# Altium schematic sheet LCD.SchDoc(LCD)
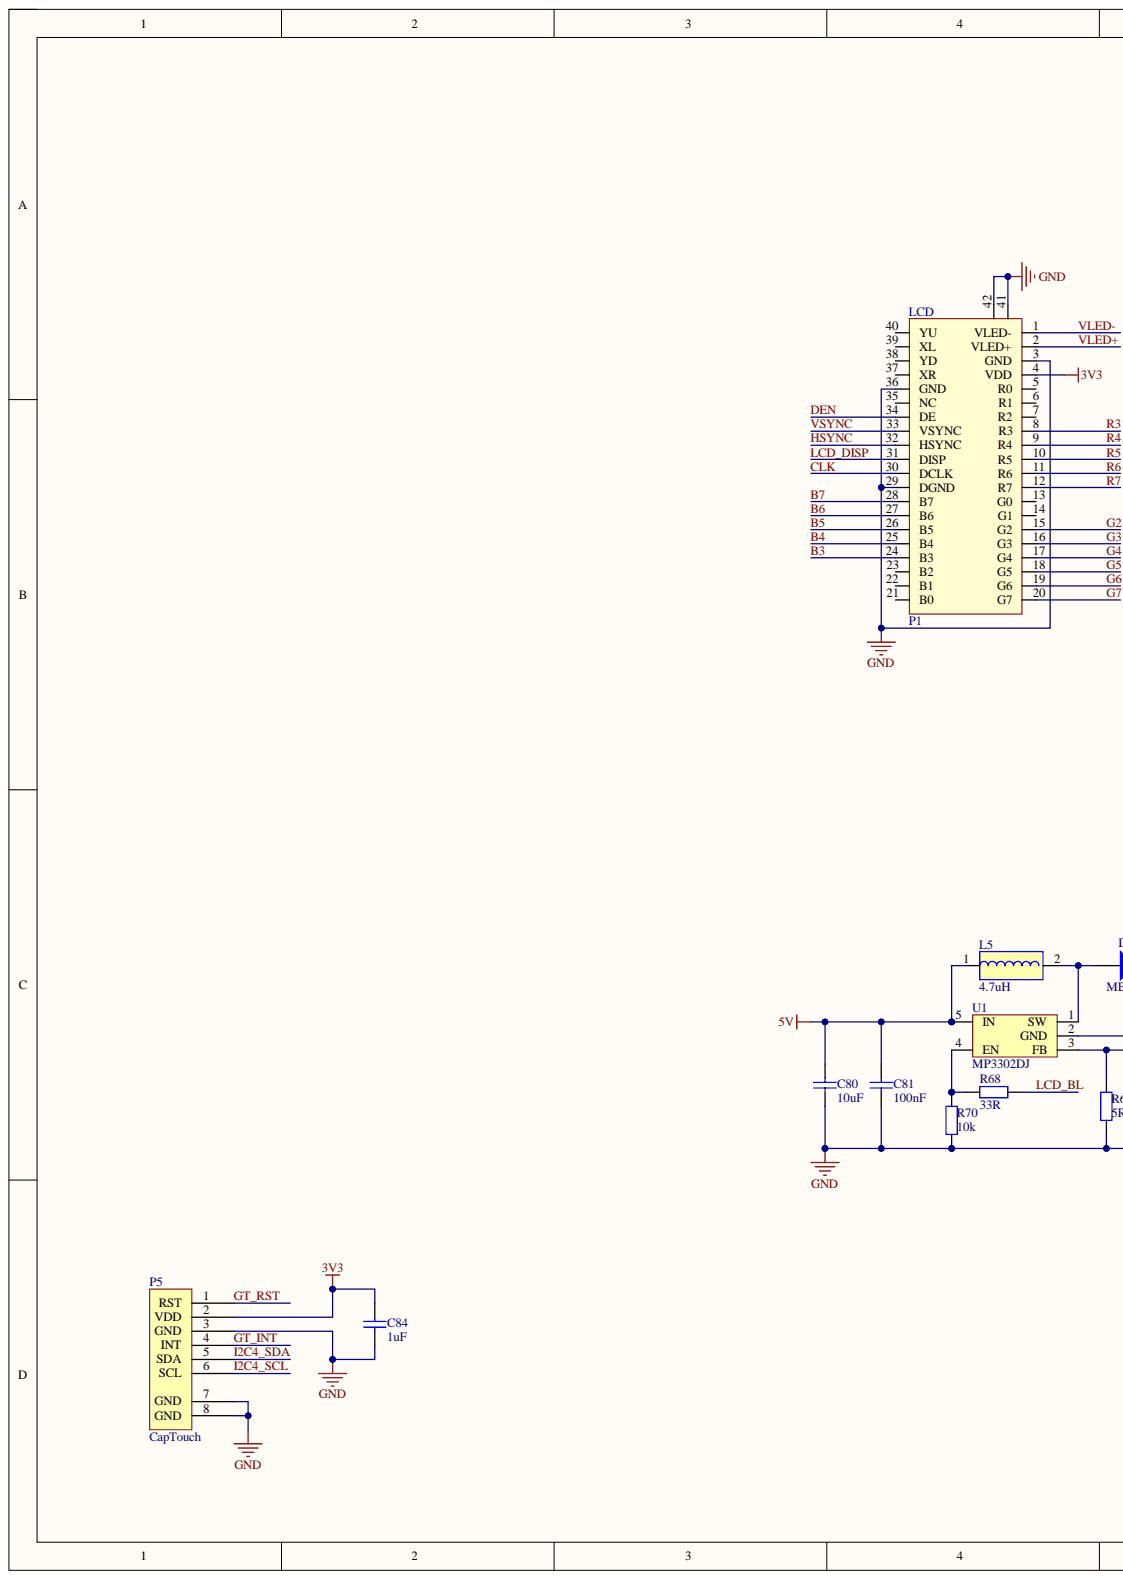
[diagram p0: LCD.SchDoc, left]
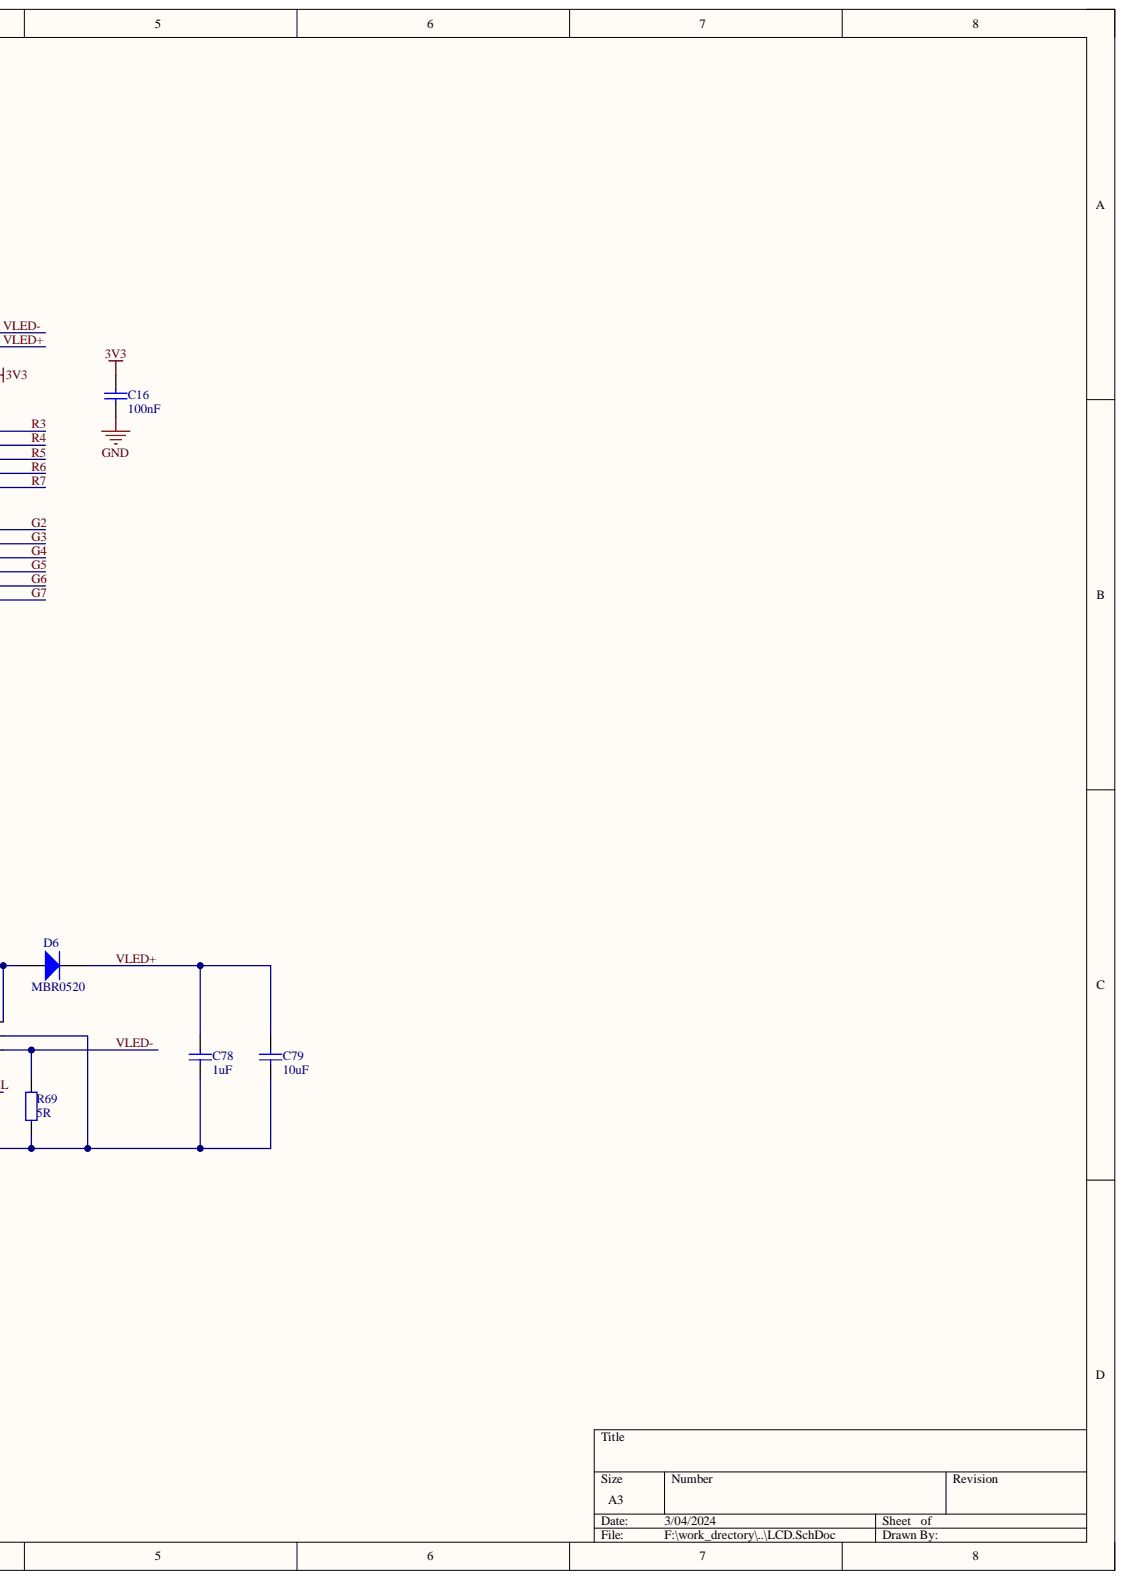
[diagram p1: LCD.SchDoc, right]

## Parts
C16  100nF  [0402_C]
C78  1uF  [0402_C]
C79  10uF  [0603_C]
C80  10uF  [0603_C]
C81  100nF  [0402_C]
C84  1uF  [0402_C]
D6  MBR0520  [SOD2513X120N]
L5  4.7uH  [L1008]
P1  LCD  [FPC0.5 2H-WS-40P]
P5  CapTouch  [FPC-SMD_P0.50-6P]
R68  33R  [0402_R]
R69  5R  [0603_R]
R70  10k  [0402_R]
U1  MP3302DJ  [SOT-23-5]

## Nets
3V3: C16.1 C84.1 P1.4(VDD) P5.2(VDD)
5V: C80.1 C81.1 L5.1 U1.5(IN)
GND: C16.2 C78.2 C79.2 C80.2 C81.2 C84.2 P1.3(GND) P1.29(DGND) P1.36(GND) P1.41 P1.42 P5.3(GND) P5.7(GND) P5.8(GND) R69.1 R70.1 U1.2(GND)
B3: P1.24(B3)
B4: P1.25(B4)
B5: P1.26(B5)
B6: P1.27(B6)
B7: P1.28(B7)
CLK: P1.30(DCLK)
DEN: P1.34(DE)
G2: P1.15(G2)
G3: P1.16(G3)
G4: P1.17(G4)
G5: P1.18(G5)
G6: P1.19(G6)
G7: P1.20(G7)
GT_INT: P5.4(INT)
GT_RST: P5.1(RST)
HSYNC: P1.32(HSYNC)
I2C4_SCL: P5.6(SCL)
I2C4_SDA: P5.5(SDA)
LCD_BL: R68.1
LCD_DISP: P1.31(DISP)
R3: P1.8(R3)
R4: P1.9(R4)
R5: P1.10(R5)
R6: P1.11(R6)
R7: P1.12(R7)
VLED+: C78.1 C79.1 D6.2 P1.2(VLED+)
VLED-: P1.1(VLED-) R69.2 U1.3(FB)
VSYNC: P1.33(VSYNC)
NetD6_1: D6.1 L5.2 U1.1(SW)
NetR68_2: R68.2 R70.2 U1.4(EN)

Not connected: P1 5(R0) 6(R1) 7(R2) 13(G0) 14(G1) 21(B0) 22(B1) 23(B2) 35(NC) 37(XR) 38(YD) 39(XL) 40(YU)

## Library data of the parts
C16 C78-C81 C84 = Cap: 25v; Component Kind: Standard; ComponentLink1Description: 供应商链接; ComponentLink1URL: http://www.szlcsc.com/product/details_1875.html; Library Name: MY_LIB.SchLib; Package: 0402; Supplier: LC; SuppliersPartNumber: C1523
D6 = SOD-123 B5819W  SL: 肖特基二极管; Component Kind: Standard; ComponentLink1Description: 供应商链接; ComponentLink1URL: http://www.szlcsc.com/product/details_9093.html; Library Name: MY_LIB.SchLib; Package: SOD-123; Supplier: LC; SuppliersPartNumber: C8598
L5 = L: 电感; Component Kind: Standard; Library Name: MY_LIB.SchLib
P1 = FPC40P_LCD: Component Kind: Standard; Library Name: MY_LIB.SchLib
P5 = FPC-6P: Component Kind: Standard; Library Name: MY_LIB.SchLib
R68-R70 = Res: 贴片电阻; value: 0Ω; Component Kind: Standard; ComponentLink1Description: 供应商链接; ComponentLink1URL: http://www.szlcsc.com/product/details_17853.html; Library Name: MY_LIB.SchLib; Package: 0402; Supplier: LC; SuppliersPartNumber: C17168
U1 = MP3302: LCD背光IC; Component Kind: Standard; Library Name: MY_LIB.SchLib
